# Altium schematic sheet 3_RAM.SchDoc(3_RAM)
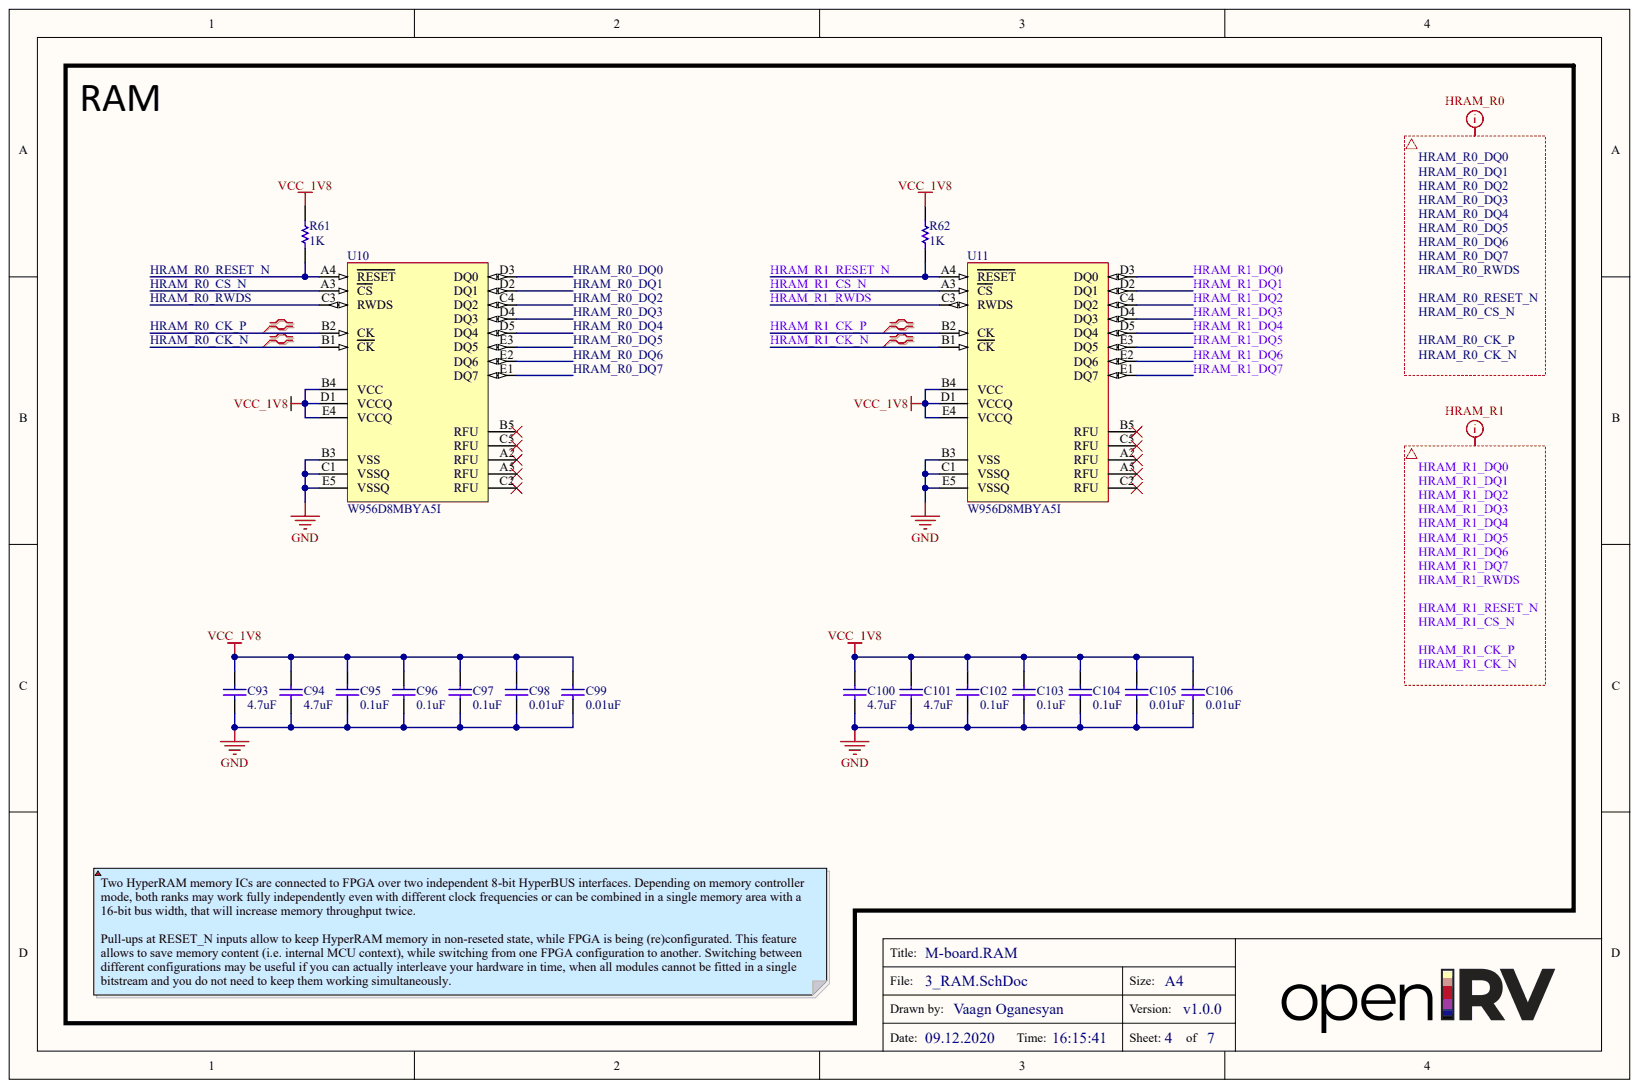
[diagram p0: 3_RAM.SchDoc]

## Parts
C93  4.7uF  [C0402[1005]]
C94  4.7uF  [C0402[1005]]
C95  0.1uF  [C0402[1005]]
C96  0.1uF  [C0402[1005]]
C97  0.1uF  [C0402[1005]]
C98  0.01uF  [C0402[1005]]
C99  0.01uF  [C0402[1005]]
C100  4.7uF  [C0402[1005]]
C101  4.7uF  [C0402[1005]]
C102  0.1uF  [C0402[1005]]
C103  0.1uF  [C0402[1005]]
C104  0.1uF  [C0402[1005]]
C105  0.01uF  [C0402[1005]]
C106  0.01uF  [C0402[1005]]
R61  1K  [R0402[1005]]
R62  1K  [R0402[1005]]
U10  W956D8MBYA5I  [W956D8MBYA5I]
U11  W956D8MBYA5I  [W956D8MBYA5I]

## Nets
GND: C93.2 C94.2 C95.2 C96.2 C97.2 C98.2 C99.2 C100.2 C101.2 C102.2 C103.2 C104.2 C105.2 C106.2 U10.B3(VSS) U10.C1(VSSQ) U10.E5(VSSQ) U11.B3(VSS) U11.C1(VSSQ) U11.E5(VSSQ)
VCC_1V8: C93.1 C94.1 C95.1 C96.1 C97.1 C98.1 C99.1 C100.1 C101.1 C102.1 C103.1 C104.1 C105.1 C106.1 R61.2 R62.2 U10.B4(VCC) U10.D1(VCCQ) U10.E4(VCCQ) U11.B4(VCC) U11.D1(VCCQ) U11.E4(VCCQ)
HRAM_R0_CK_N: U10.B1(CK)
HRAM_R0_CK_P: U10.B2(CK)
HRAM_R0_CS_N: U10.A3(CS)
HRAM_R0_DQ0: U10.D3(DQ0)
HRAM_R0_DQ1: U10.D2(DQ1)
HRAM_R0_DQ2: U10.C4(DQ2)
HRAM_R0_DQ3: U10.D4(DQ3)
HRAM_R0_DQ4: U10.D5(DQ4)
HRAM_R0_DQ5: U10.E3(DQ5)
HRAM_R0_DQ6: U10.E2(DQ6)
HRAM_R0_DQ7: U10.E1(DQ7)
HRAM_R0_RESET_N: R61.1 U10.A4(RESET)
HRAM_R0_RWDS: U10.C3(RWDS)
HRAM_R1_CK_N: U11.B1(CK)
HRAM_R1_CK_P: U11.B2(CK)
HRAM_R1_CS_N: U11.A3(CS)
HRAM_R1_DQ0: U11.D3(DQ0)
HRAM_R1_DQ1: U11.D2(DQ1)
HRAM_R1_DQ2: U11.C4(DQ2)
HRAM_R1_DQ3: U11.D4(DQ3)
HRAM_R1_DQ4: U11.D5(DQ4)
HRAM_R1_DQ5: U11.E3(DQ5)
HRAM_R1_DQ6: U11.E2(DQ6)
HRAM_R1_DQ7: U11.E1(DQ7)
HRAM_R1_RESET_N: R62.1 U11.A4(RESET)
HRAM_R1_RWDS: U11.C3(RWDS)
N00197:
N00198:

Not connected: U10 A2(RFU) A5(RFU) B5(RFU) C2(RFU) C5(RFU); U11 A2(RFU) A5(RFU) B5(RFU) C2(RFU) C5(RFU)

## Library data of the parts
C93 C94 C100 C101 = CAP: Dielectric: X5R; Tolerance: 10%; Voltage: 6.3V; Component Kind: Standard; Library Name: general.IntLib; Mounting: SMD; Value: 4.7uF
C95-C99 C102-C106 = CAP: Dielectric: X7R; Tolerance: 10%; Voltage: 50V; Component Kind: Standard; Library Name: general.IntLib; Mounting: SMD
  C95-C97 C102-C104: Value: 0.1uF
  C98 C99 C105 C106: Value: 0.01uF
R61 R62 = RES: Power: 0.06W; Tolerance: 5%; Component Kind: Standard; Library Name: general.IntLib; Mounting: SMD; Value: 1K
U10 U11 = W956D8MBYA5I: HyperBus PSRAM 64Mbit; Component Kind: Standard; Library Name: general.IntLib
